# Altium schematic sheet Sheet1.SchDoc
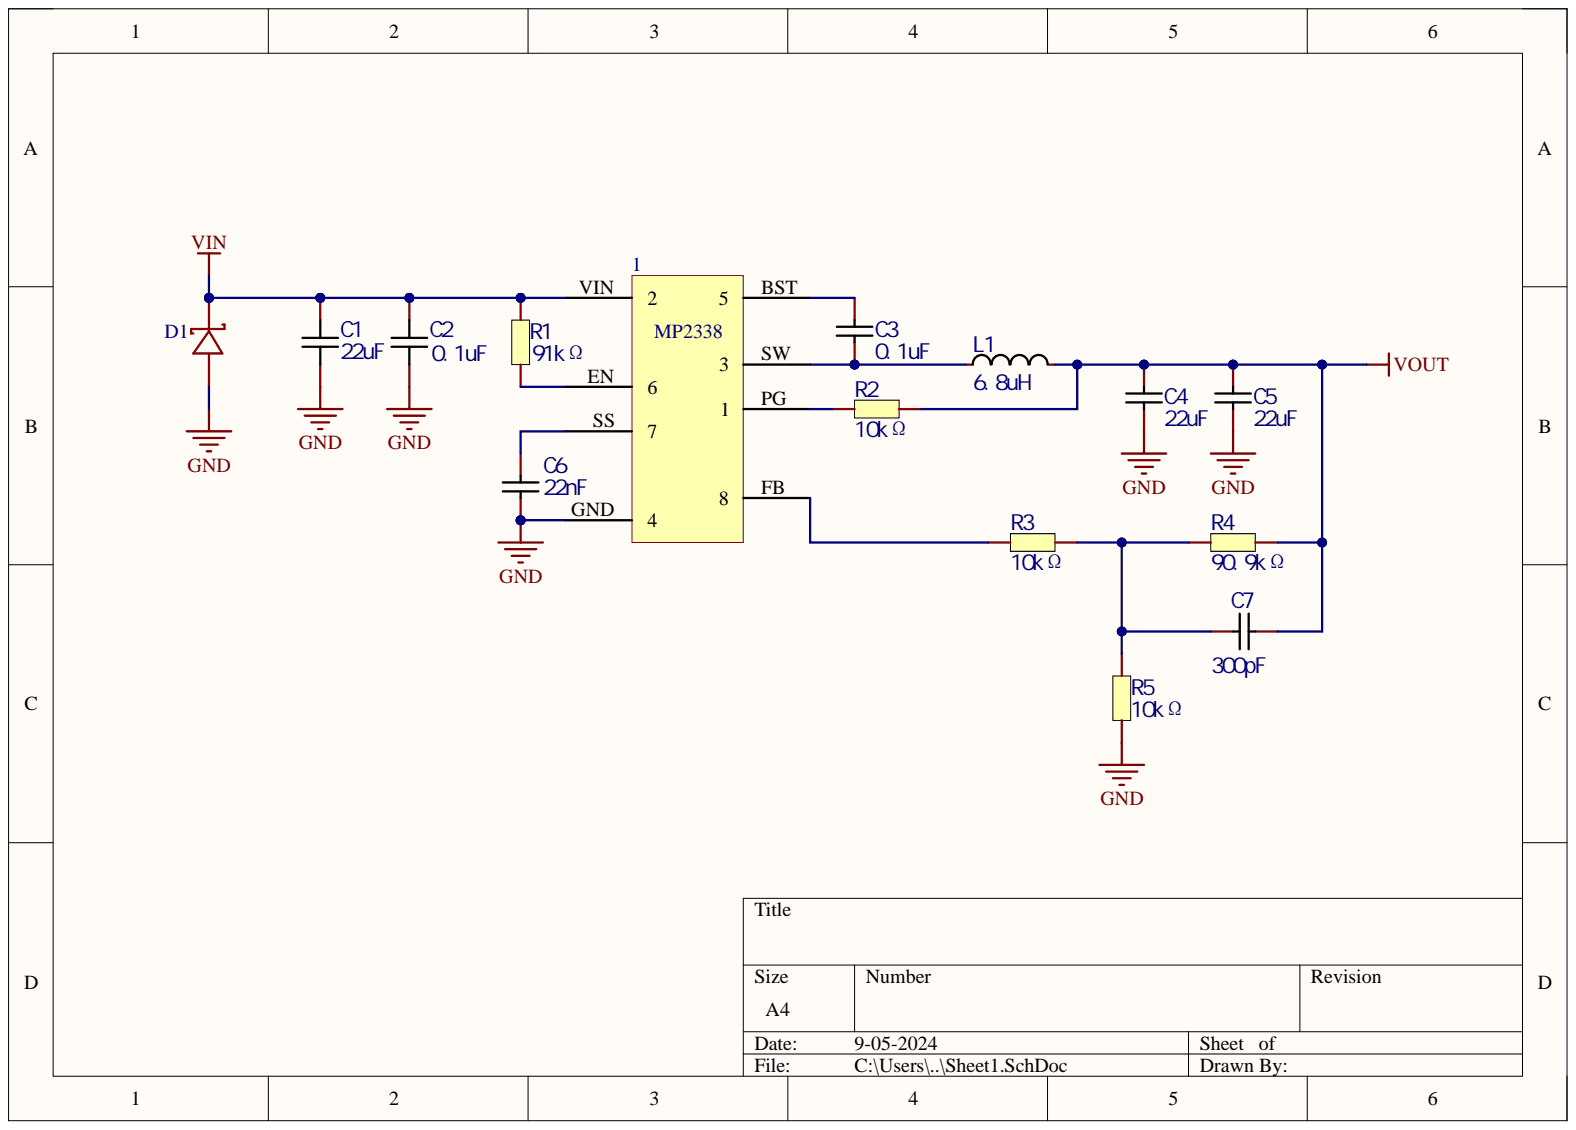
[diagram p0: Sheet1.SchDoc]

## Parts
1  MP2338  [PCBComponent_1]
*  D1  [PCBComponent_1]
C1  22uF  [C0805]
C2  0.1uF  [C0805]
C3  0.1uF  [C0603]
C4  22uF  [C0603]
C5  22uF  [C0603]
C6  22nF  [C0603]
C7  300pF  [C0603]
L1  6.8uH  [IND-SMD_L7.0-W6.6]
R1  191kΩ  [R0603]
R2  10kΩ  [R0603]
R3  10kΩ  [R0603]
R4  90.9kΩ  [R0603]
R5  10kΩ  [R0603]

## Nets
GND: 1.GND *.2 C1.1 C2.1 C4.1 C5.1 C6.1 R5.1
VIN: 1.VIN *.1 C1.2 C2.2 R1.2
VOUT: C4.2 C5.2 C7.2 L1.2 R2.2 R4.2
Net1_BST: 1.BST C3.2
Net1_EN: 1.EN R1.1
Net1_FB: 1.FB R3.1
Net1_PG: 1.PG R2.1
Net1_SS: 1.SS C6.2
Net1_SW: 1.SW C3.1 L1.1
NetC7_1: C7.1 R3.2 R4.1 R5.2

## Library data of the parts
* = Component_1: Component Kind: Standard; Library Name: TVS.SchLib
C1 C2 = CL21A226MAQNNNE_C45783: 容值:22uF;精度:±20%;额定电压:25V;材质(温度系数):X5R;; Manufacturer: SAMSUNG(三星); Add into BOM: yes; Temperature Coefficient: X5R; Tolerance: ±20%; Voltage Rated: 25V; Component Kind: Standard; Convert to PCB: yes; Datasheet: https://atta.szlcsc.com/upload/public/pdf/source/20160103/1457707727161.pdf; Device: CL21A226MAQNNNE_C45783; JLCPCB Part Class: 基础库; LCSC Part Name: 22uF ±20% 25V; Manufacturer Part: CL21A226MAQNNNE; PCB3D: C0805_L2.0-W1.3-H1.3; Supplier: LCSC; Supplier Footprint: 0805; Supplier Part: C45783; Symbol: CL21A226MAQNNNE; Library Name: YHT_Y170_SCH.SCHLIB
  C1: Name: 22uF; Value: 22uF
  C2: Name: 0.1uF; Value: 0.1uF
C3-C7 = CL10A225KO8NNNC: 容值:2.2uF;精度:±10%;额定电压:16V;材质(温度系数):X5R;; Manufacturer: SAMSUNG(三星); Add into BOM: yes; Temperature Coefficient: X5R; Tolerance: ±10%; Voltage Rated: 16V; Component Kind: Standard; Convert to PCB: yes; Datasheet: https://atta.szlcsc.com/upload/public/pdf/source/20160103/1457707709512.pdf; Device: CL10A225KO8NNNC; JLCPCB Part Class: 基础库; LCSC Part Name: 2.2uF ±10% 16V; Manufacturer Part: CL10A225KO8NNNC; PCB3D: C0603_L1.6-W0.8-H0.8; Supplier: LCSC; Supplier Footprint: 0603; Supplier Part: C23630; Symbol: CL10A225KO8NNNC; Library Name: YHT_Y170_SCH.SCHLIB
  C3: Name: 0.1uF; Value: 0.1uF
  C4 C5: Name: 22uF; Value: 22uF
  C6: Name: 22nF; Value: 22nF
  C7: Name: 300pF; Value: 300pF
L1 = MWSA0603S-2R2MT: 电感值:2.2uH;精度:±20%;额定电流:9.5A;直流电阻(DCR):15mΩ;; Manufacturer: Sunlord(顺络); Add into BOM: yes; DC Resistance (DCR): 15mΩ; Rated Current: 9.5A; Tolerance: ±20%; Component Kind: Standard; Convert to PCB: yes; Datasheet: https://atta.szlcsc.com/upload/public/pdf/source/20190729/C408445_4286F301FFB17FE93B4CE8B15A01B108.pdf; Device: MWSA0603S-2R2MT; JLCPCB Part Class: 扩展库; LCSC Part Name: 2.2uH ±20% 9.5A 15mΩ; Manufacturer Part: MWSA0603S-2R2MT; Name: 6.8uH; PCB3D: IND-SMD_L7.0-W6.6-H3.0; Supplier: LCSC; Supplier Footprint: SMD; Supplier Part: C408445; Symbol: MWSA0603S-2R2MT; Value: 6.8uH; Library Name: YHT_Y170_SCH.SCHLIB
R1-R5 = 0603WAF1002T5E: 电阻类型:厚膜电阻;阻值:10kΩ;精度:±1%;功率:100mW;温度系数:±100ppm/℃;最大工作电压:75V;工作温度范围:-55℃~+155℃;; Manufacturer: UNI-ROYAL(厚声); Add into BOM: yes; Overload Voltage (Max): 75V; Power(Watts): 100mW; Tolerance: ±1%; Component Kind: Standard; Convert to PCB: yes; Datasheet: https://atta.szlcsc.com/upload/public/pdf/source/20200306/C422600_1E6D84923E4A46A82E41ADD87F860B5C.pdf; Device: 0603WAF1002T5E; JLCPCB Part Class: 基础库; LCSC Part Name: 10kΩ ±1% 100mW; Manufacturer Part: 0603WAF1002T5E; Operating Temperature Range: -55℃~+155℃; PCB3D: R0603; Supplier: LCSC; Supplier Footprint: 0603; Supplier Part: C25804; Symbol: 0603WAF1002T5E; Temperature Coefficient: ±100ppm/℃; Type: 厚膜电阻; Library Name: YHT_Y170_SCH.SCHLIB
  R1: Name: 191kΩ; Value: 191kΩ
  R2 R3 R5: Name: 10kΩ; Value: 10kΩ
  R4: Name: 90.9kΩ; Value: 90.9kΩ
1 = MP2338: Component Kind: Standard; Library Name: Schlib1.SchLib
